# Altium schematic sheet Card ID.SCHDOC("Card ID")
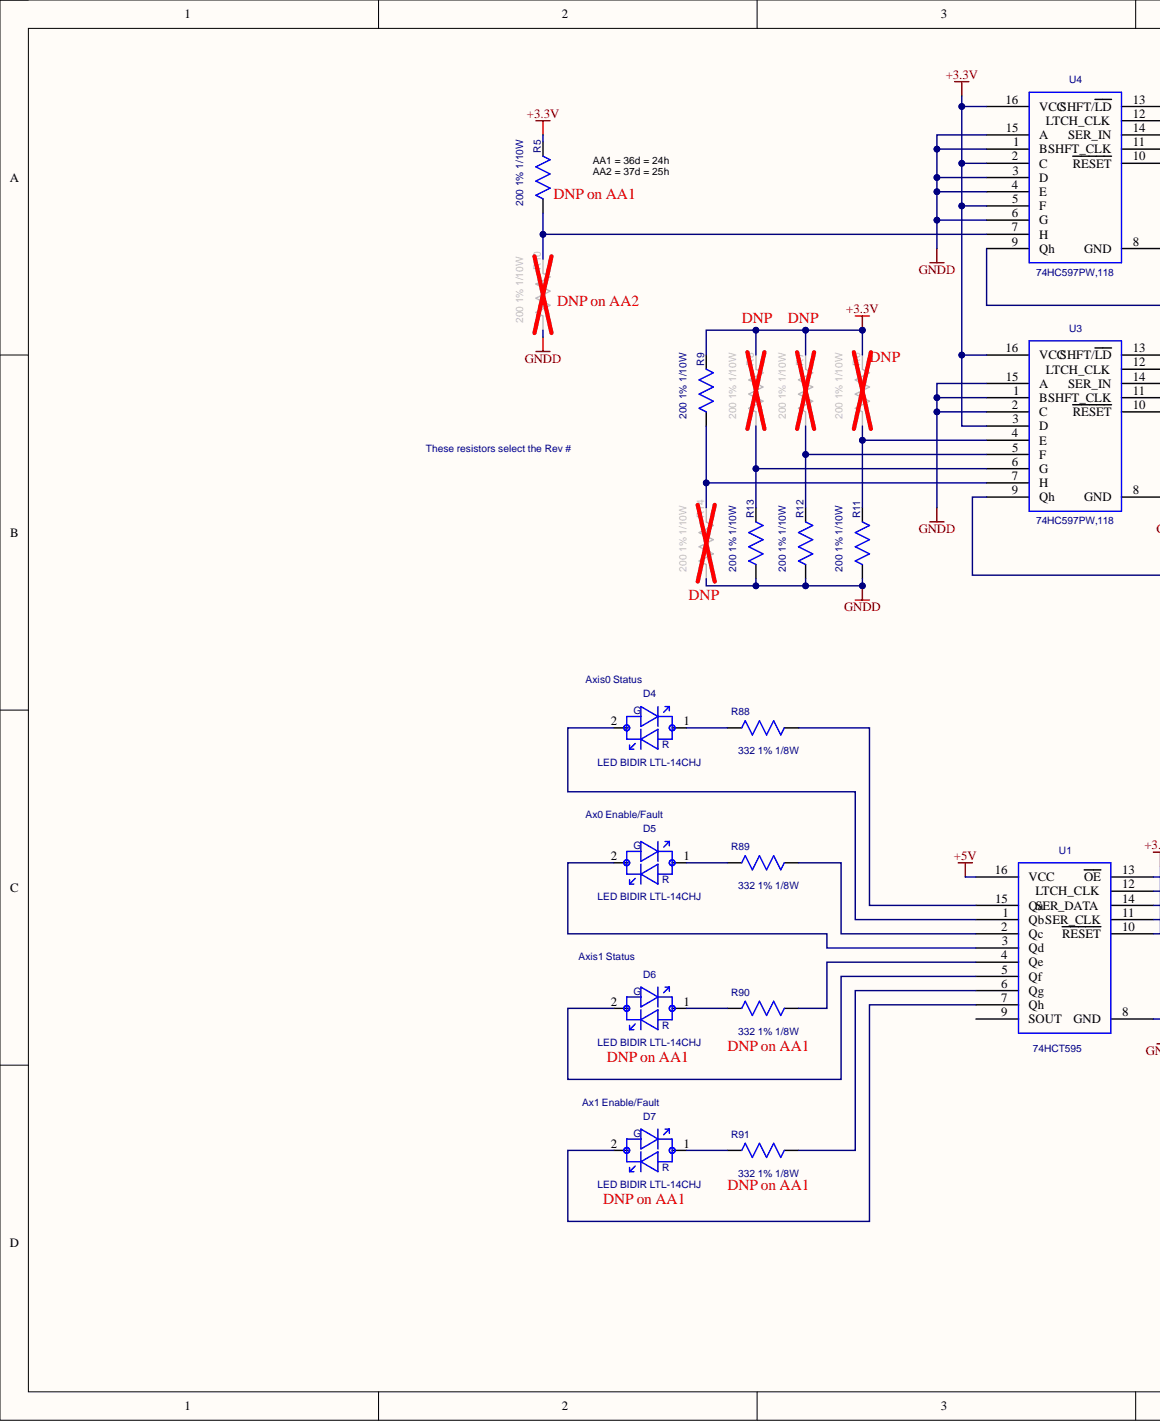
[diagram p0: Card ID.SCHDOC, left]
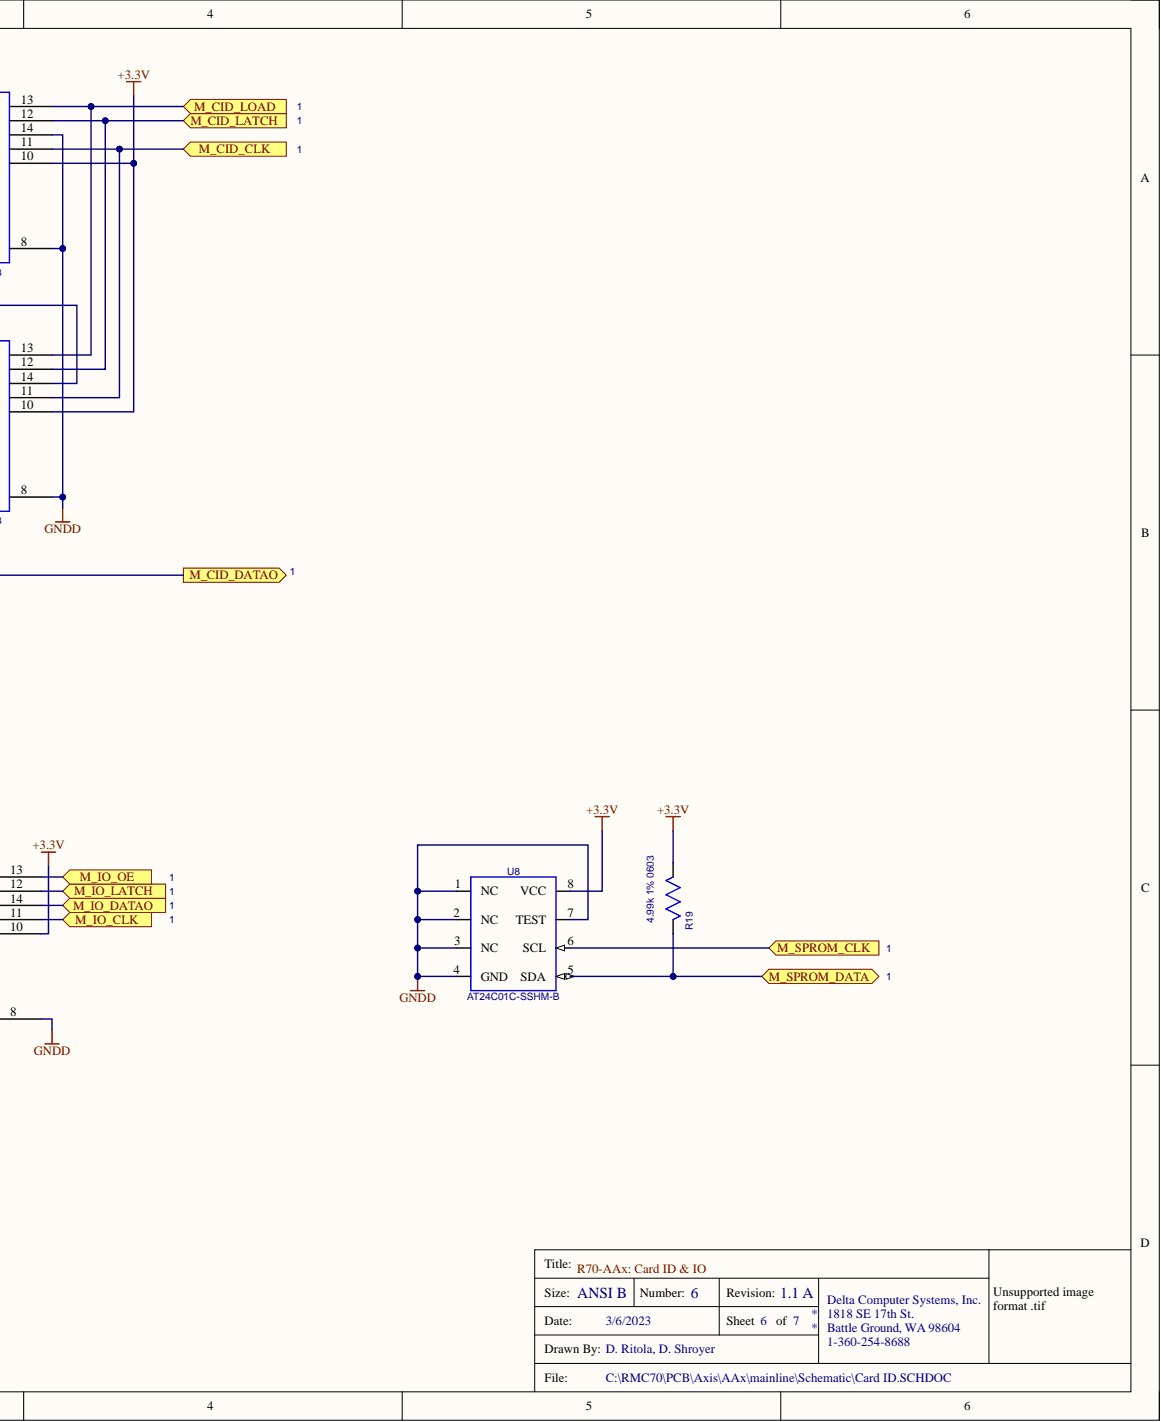
[diagram p1: Card ID.SCHDOC, right]

## Parts
D4  LED BIDIR LTL-14CHJ  PartNumber: LTL-14CHJ  [LED 0.1 VERT]
D5  LED BIDIR LTL-14CHJ  PartNumber: LTL-14CHJ  [LED 0.1 VERT]
D6  LED BIDIR LTL-14CHJ  PartNumber: LTL-14CHJ  [LED 0.1 VERT]
D7  LED BIDIR LTL-14CHJ  PartNumber: LTL-14CHJ  [LED 0.1 VERT]
R5  200 1% 1/10W  [CC0603]
R6  200 1% 1/10W  [CC0603]
R7  200 1% 1/10W  [CC0603]
R8  200 1% 1/10W  [CC0603]
R9  200 1% 1/10W  [CC0603]
R10  200 1% 1/10W  [CC0603]
R11  200 1% 1/10W  [CC0603]
R12  200 1% 1/10W  [CC0603]
R13  200 1% 1/10W  [CC0603]
R14  200 1% 1/10W  [CC0603]
R19  4.99k 1% 0603  [CC0603]
R88  332 1% 1/8W  [CC0805]
R89  332 1% 1/8W  [CC0805]
R90  332 1% 1/8W  [CC0805]
R91  332 1% 1/8W  [CC0805]
U1  74HCT595  [TSSOP16]
U3  74HC597PW,118  [TSSOP16]
U4  74HC597PW,118  [TSSOP16]
U8  AT24C01C-SSHM-B  [SO8]

## Ports
M_CID_CLK, M_CID_DATAO, M_CID_LATCH, M_CID_LOAD, M_IO_CLK, M_IO_DATAO, M_IO_LATCH, M_IO_OE, M_SPROM_CLK, M_SPROM_DATA

## Nets
+3.3V: R5.2 R6.2 R7.2 R8.2 R9.2 R19.2 U1.10(RESET) U3.3(D) U3.10(RESET) U3.16(VCC) U4.2(C) U4.5(F) U4.10(RESET) U4.16(VCC) U8.8(VCC)
+5V: U1.16(VCC)
GNDD: R10.1 R11.1 R12.1 R13.1 R14.1 U1.8(GND) U3.1(B) U3.2(C) U3.8(GND) U3.15(A) U4.1(B) U4.3(D) U4.4(E) U4.6(G) U4.8(GND) U4.14(SER_IN) U4.15(A) U8.1(NC) U8.2(NC) U8.3(NC) U8.4(GND) U8.7(TEST)
M_CID_CLK: U3.11(SHFT_CLK) U4.11(SHFT_CLK) ; port M_CID_CLK
M_CID_DATAO: U3.9(Qh) ; port M_CID_DATAO
M_CID_LATCH: U3.12(LTCH_CLK) U4.12(LTCH_CLK) ; port M_CID_LATCH
M_CID_LOAD: U3.13(SHFT/LD) U4.13(SHFT/LD) ; port M_CID_LOAD
M_IO_CLK: U1.11(SER_CLK) ; port M_IO_CLK
M_IO_DATAO: U1.14(SER_DATA) ; port M_IO_DATAO
M_IO_LATCH: U1.12(LTCH_CLK) ; port M_IO_LATCH
M_IO_OE: U1.13(OE) ; port M_IO_OE
M_SPROM_CLK: U8.6(SCL) ; port M_SPROM_CLK
M_SPROM_DATA: R19.1 U8.5(SDA) ; port M_SPROM_DATA
NetD4_1: D4.1 R88.1
NetD4_2: D4.2 U1.1(Qb)
NetD5_1: D5.1 R89.1
NetD5_2: D5.2 U1.3(Qd)
NetD6_1: D6.1 R90.1
NetD6_2: D6.2 U1.5(Qf)
NetD7_1: D7.1 R91.1
NetD7_2: D7.2 U1.7(Qh)
NetR5_1: R5.1 R10.2 U4.7(H)
NetR6_1: R6.1 R11.2 U3.4(E)
NetR7_1: R7.1 R12.2 U3.5(F)
NetR8_1: R8.1 R13.2 U3.6(G)
NetR9_1: R9.1 R14.2 U3.7(H)
NetR88_2: R88.2 U1.15(Qa)
NetR89_2: R89.2 U1.2(Qc)
NetR90_2: R90.2 U1.4(Qe)
NetR91_2: R91.2 U1.6(Qg)
NetU3_14: U3.14(SER_IN) U4.9(Qh)

Not connected: U1 9(SOUT)

## Library data of the parts
D4-D7 = LED BIDIR LTL-14CHJ: LED Green/Red Diff 3mm Round T1; Manufacturer: Lite-On; Component Kind: Standard
R5-R14 = RES_GEN_SMT0603: Res 200 1% 1/10W 0603; Manufacturer: Venkel; PartNumber: CR0603-10W-2000FT; Component Kind: Standard; Value: 200 1% 1/10W
R19 = RES_GEN_SMT0603: Res 4.99k 1% 1/10W 0603; Manufacturer: Venkel; PartNumber: CR0603-10W-4991FT; Notes: ERA0020; Component Kind: Standard; Value: 4.99k 1% 0603
R88-R91 = RES_GEN_SMT0805: Res 332 1% 1/8W 0805; Manufacturer: Venkel; PartNumber: CR0805-8W-3320FT; Component Kind: Standard; Value: 332 1% 1/8W
U1 = 74HC595 TSSOP16: Shift Register 3St 8bit 4.5~5.5V 16TSSOP; Manufacturer: NXP; PartNumber: 74HCT595PW,118; Component Kind: Standard; Value: 74HCT595
U3 U4 = 74HC597A TSSOP16: Shift Register Push-Pull 16TSSOP; Manufacturer: NXP; Component Kind: Standard; PartNumber: 74HC597PW,118
U8 = AT24C01-10SI: Serial EEPROM 1Kbit 1MHz 8SOIC; Manufacturer: Microchip; PartNumberAlt1: 24AA014H-I/SN; Notes: EUM0013; Component Kind: Standard; ManufacturerAlt1: Microchip; PartNumber: AT24C01C-SSHM-B
